# Altium schematic sheet StepMotor3.SchDoc
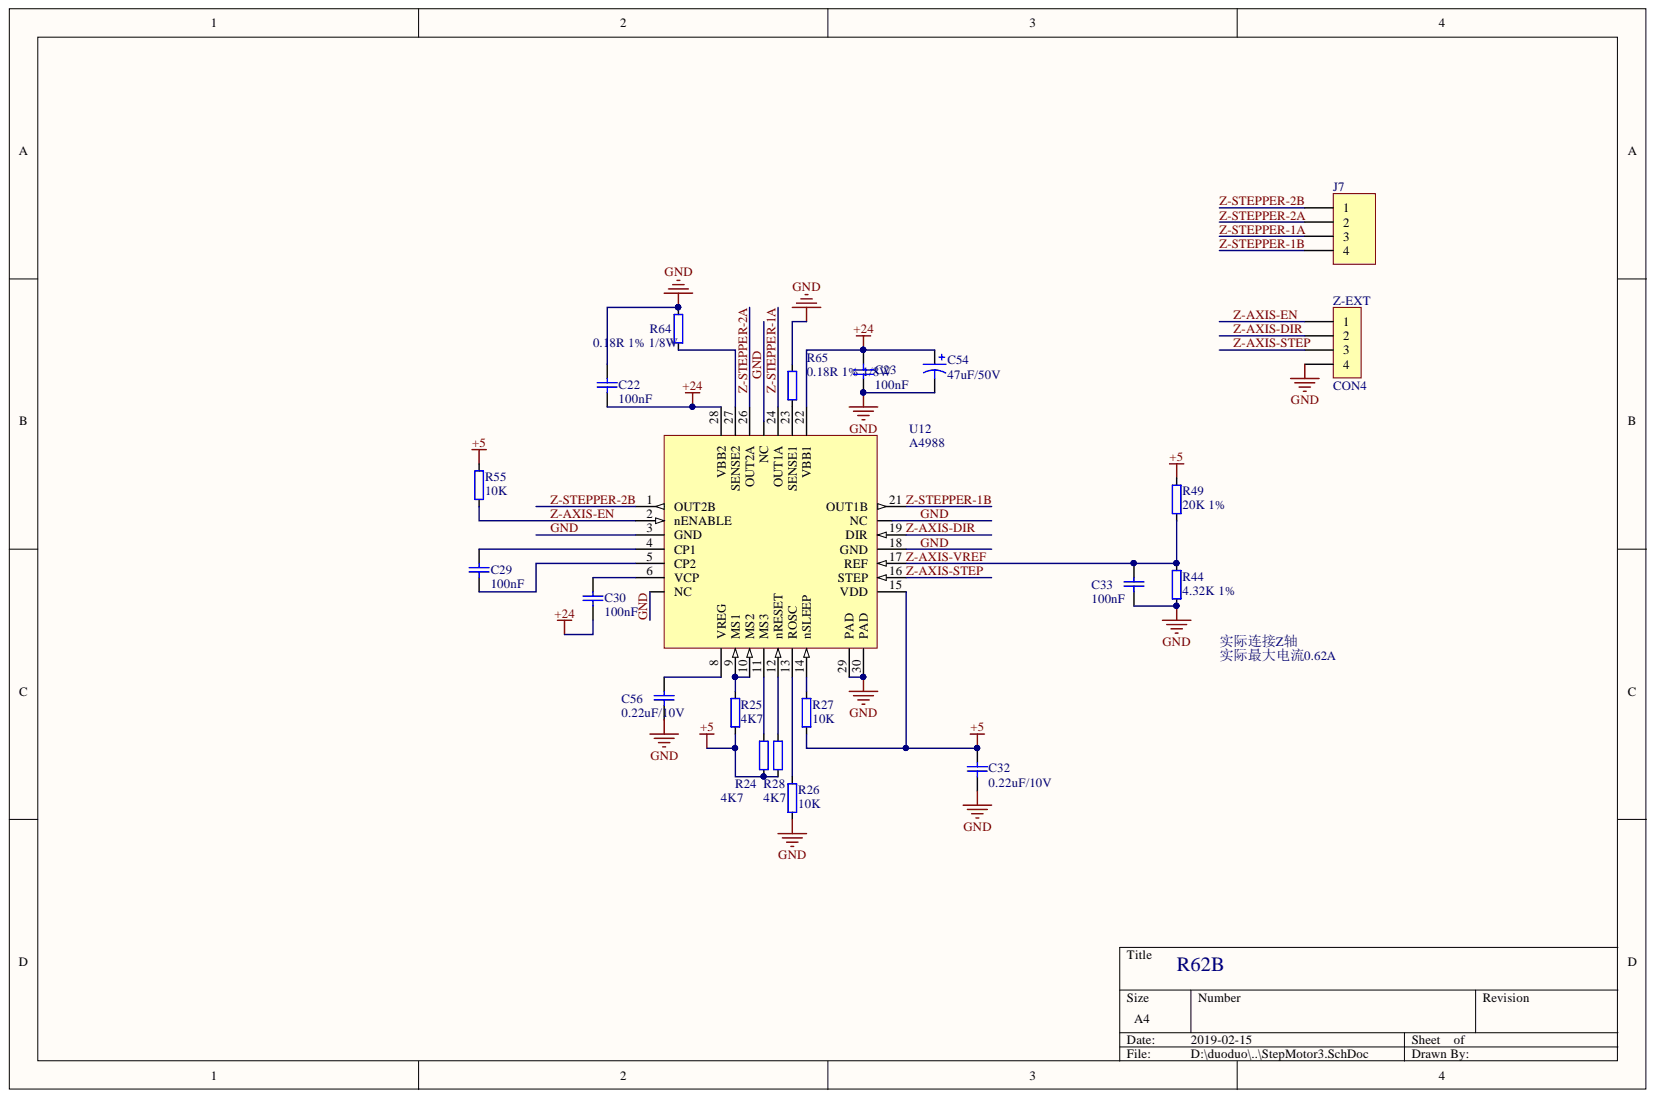
[diagram p0: StepMotor3.SchDoc]

## Parts
C22  100nF  [0603]
C23  100nF  [0603]
C29  100nF  [0603]
C30  100nF  [0603]
C32  0.22uF/10V  [0603]
C33  100nF  [0603]
C54  47uF/50V  [EC-6.3]
C56  0.22uF/10V  [0603]
J7  Header 4  [XH2.54-4-A]  (value not drawn)
R24  4K7  [0603]
R25  4K7  [0603]
R26  10K  [0603]
R27  10K  [0603]
R28  4K7  [0603]
R44  4.32K 1%  [0603]
R49  20K 1%  [0603]
R55  10K  [0603]
R64  0.18R 1% 1/8W  [0805]
R65  0.18R 1% 1/8W  [0805]
U12  A4988  [QFN-28]
Z-EXT  CON4  [SIP-4-XH]

## Nets
+5: C32.1 R24.2 R25.2 R27.2 R28.2 R49.2 R55.2 U12.15(VDD)
+24: C22.2 C23.2 C30.2 C54.1 U12.22(VBB1) U12.28(VBB2)
GND: C22.1 C23.1 C32.2 C33.2 C54.2 C56.2 R26.2 R44.2 R64.2 R65.2 U12.3(GND) U12.7(NC) U12.18(GND) U12.20(NC) U12.25(NC) U12.29(PAD) U12.30(PAD) Z-EXT.4
Z-AXIS-DIR: U12.19(DIR) Z-EXT.2
Z-AXIS-EN: R55.1 U12.2(nENABLE) Z-EXT.1
Z-AXIS-STEP: U12.16(STEP) Z-EXT.3
Z-AXIS-VREF: C33.1 R44.1 R49.1 U12.17(REF)
Z-STEPPER-1A: J7.3 U12.24(OUT1A)
Z-STEPPER-1B: J7.4 U12.21(OUT1B)
Z-STEPPER-2A: J7.2 U12.26(OUT2A)
Z-STEPPER-2B: J7.1 U12.1(OUT2B)
NetC29_1: C29.1 U12.4(CP1)
NetC29_2: C29.2 U12.5(CP2)
NetC30_1: C30.1 U12.6(VCP)
NetC56_1: C56.1 U12.8(VREG)
NetR24_1: R24.1 U12.11(MS3)
NetR25_1: R25.1 U12.9(MS1) U12.10(MS2)
NetR26_1: R26.1 U12.13(ROSC)
NetR27_1: R27.1 U12.14(nSLEEP)
NetR28_1: R28.1 U12.12(nRESET)
NetR64_1: R64.1 U12.27(SENSE2)
NetR65_1: R65.1 U12.23(SENSE1)

## Library data of the parts
C22 C23 C29 C30 C32 C33 C56 = CAP: Capacitor; Component Kind: Standard; Library Name: DMSch.SCHLIB
C54 = Cap Pol1: Polarized Capacitor (Radial); Component Kind: Standard; Library Name: Miscellaneous Devices.IntLib; Signal Integrity: Cap Pol; Simulation: CAP
J7 = Header 4: Header, 4-Pin; Component Kind: Standard; LatestRevisionDate: 17-Jul-2002; LatestRevisionNote: Re-released for DXP Platform.; Library Name: Miscellaneous Connectors.IntLib; Signal Integrity: Connector; Publisher: Altium Limited
R24-R28 R44 R49 R55 R64 R65 = RES3: Component Kind: Standard; Library Name: DMSch.SCHLIB
U12 = A4988-QFN28: A4982 Step Driver; Component Kind: Standard; Library Name: DMSch.SCHLIB
Z-EXT = CON4: Connector; Component Kind: Standard; Library Name: Miscellaneous Devices.SCHLIB
